# Altium schematic sheet ГРЛМ.468389.001 Э3.SchDoc
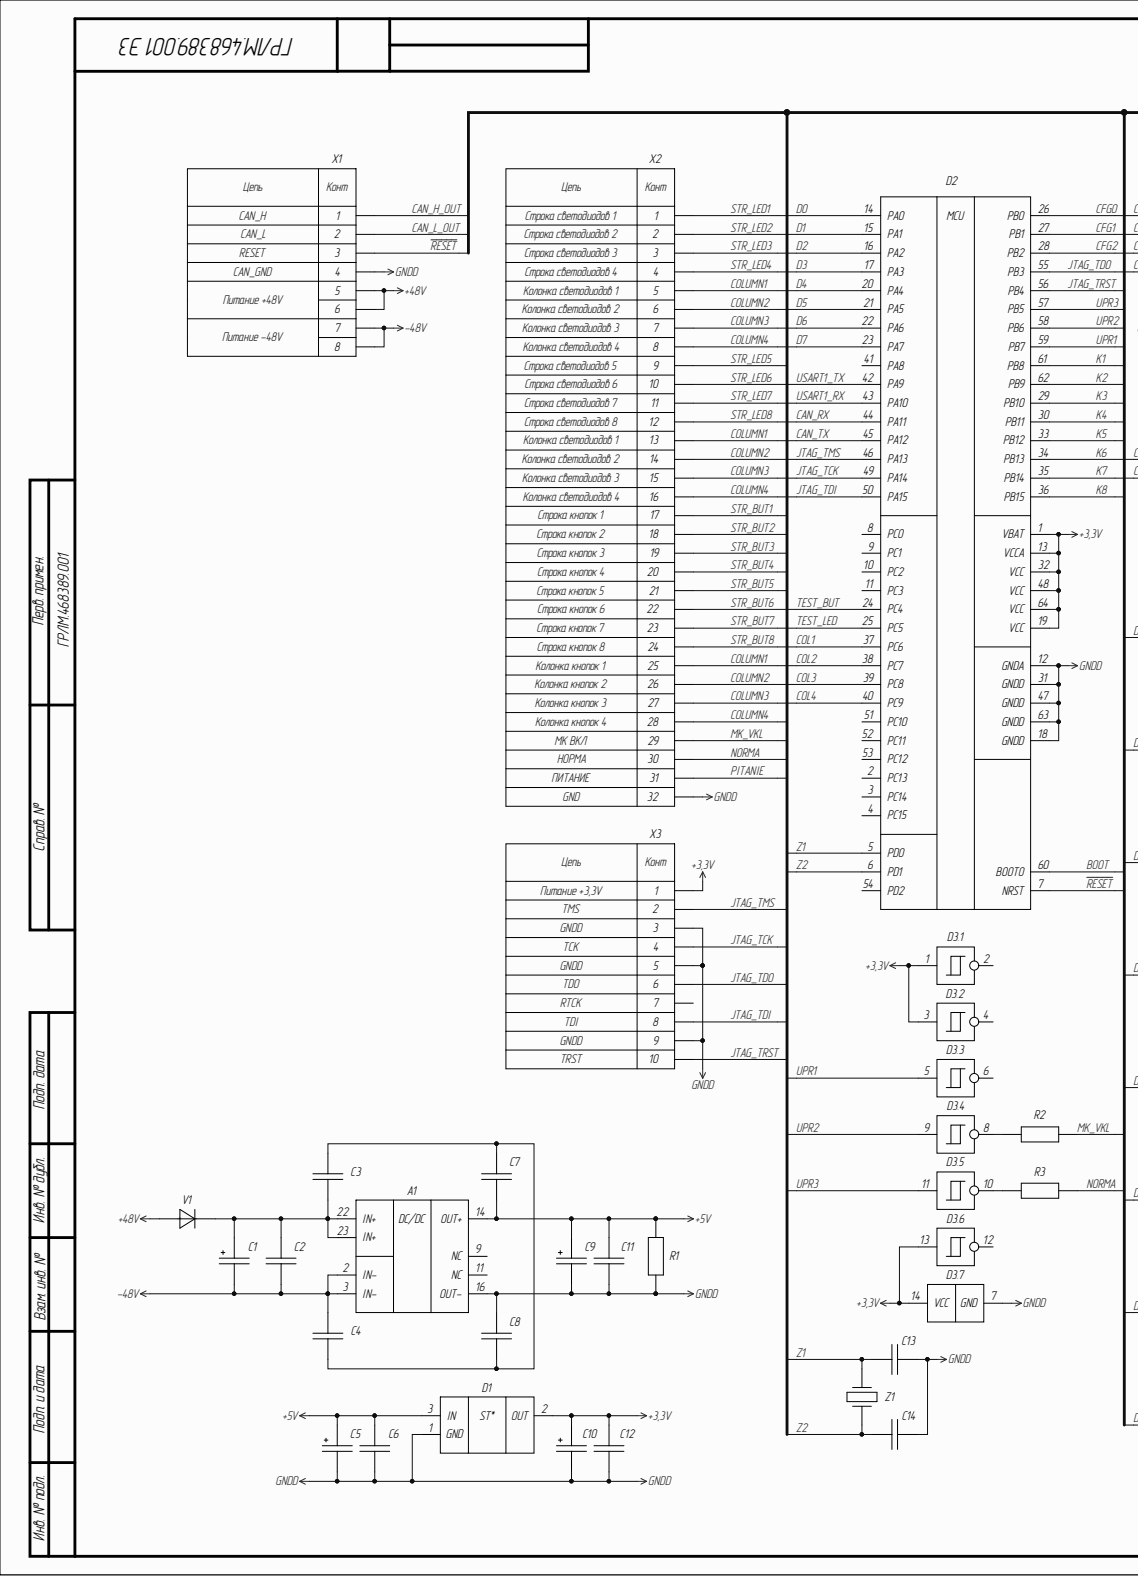
[diagram p0: ГРЛМ.468389.001 Э3.SchDoc, left]
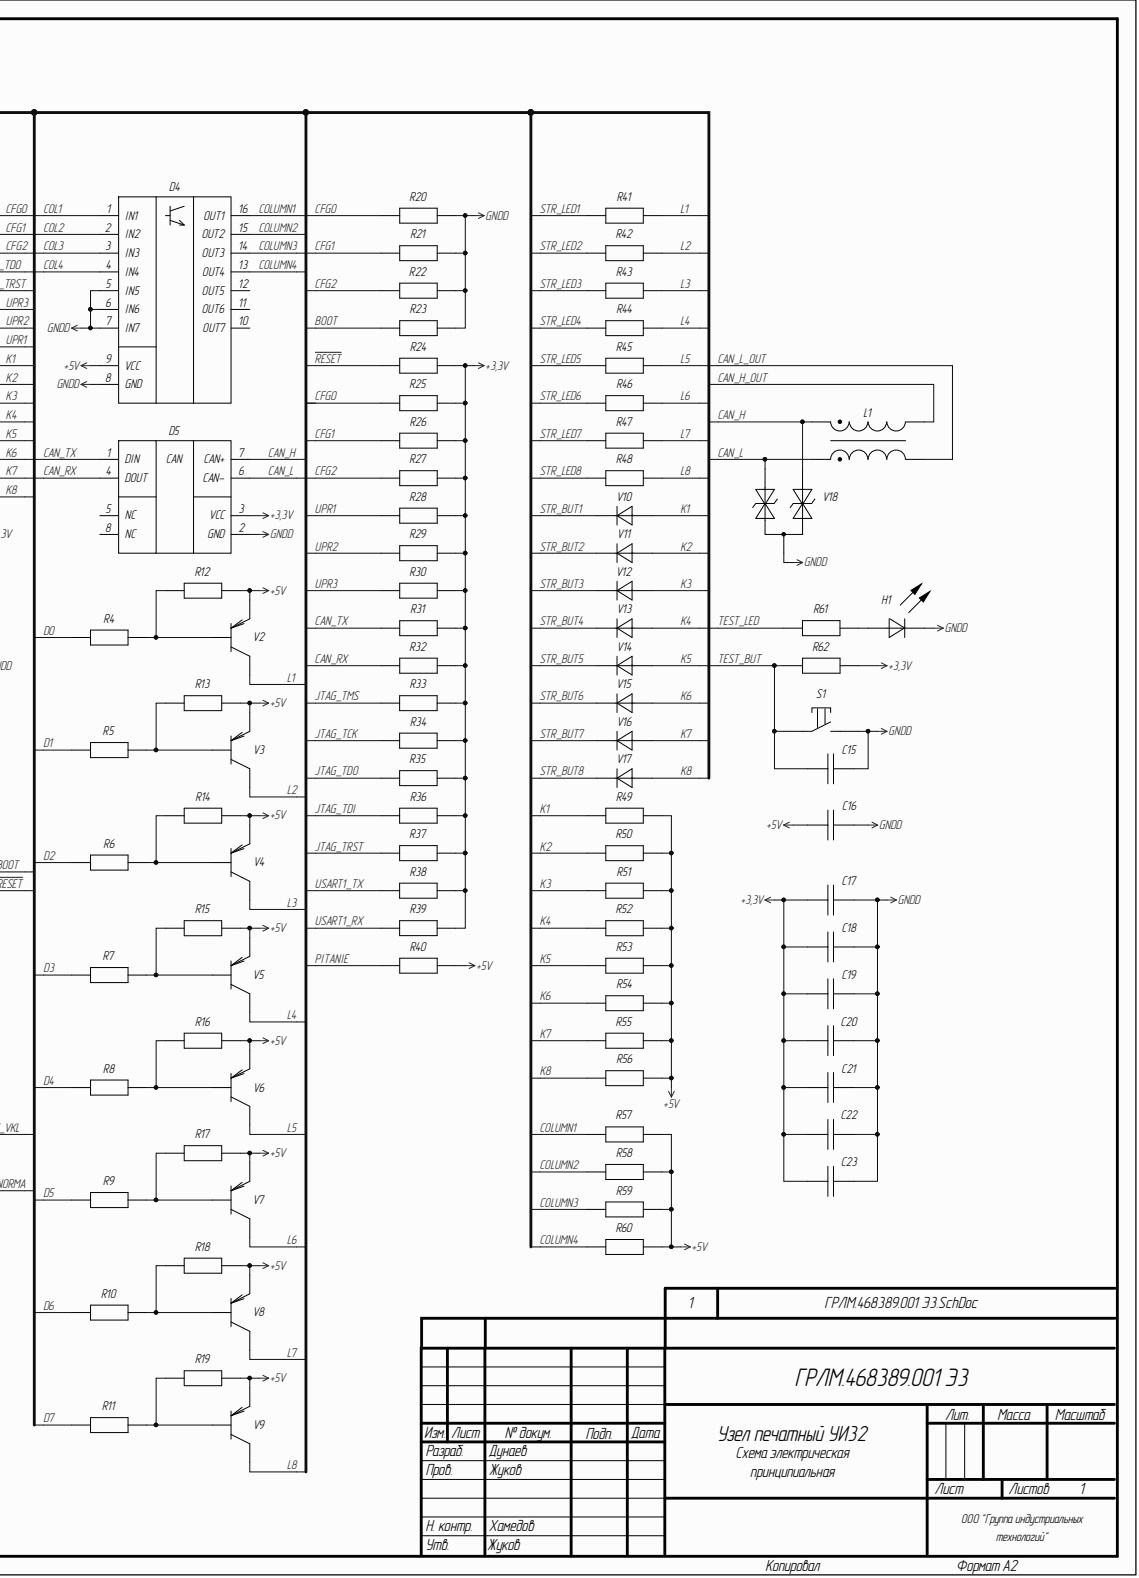
[diagram p1: ГРЛМ.468389.001 Э3.SchDoc, right]

## Parts
A1  SCW05С-05  [SCW05C-05]  (value not drawn)
C1  RADCAP_5x11  [CAPPR500-1000X1600]  (value not drawn)
C2  CERCAP_1206  [CAP1206]  (value not drawn)
C3  CERCAP_1206  [CAP1206]  (value not drawn)
C4  CERCAP_1206  [CAP1206]  (value not drawn)
C5  TANCAP_A  [CAP-T-A]  (value not drawn)
C6  CERCAP_0805  [CAP0805]  (value not drawn)
C7  CERCAP_0805  [CAP0805]  (value not drawn)
C8  CERCAP_0805  [CAP0805]  (value not drawn)
C9  TANCAP_A  [CAP-T-A]  (value not drawn)
C10  TANCAP_A  [CAP-T-A]  (value not drawn)
C11  CERCAP_0805  [CAP0805]  (value not drawn)
C12  CERCAP_0805  [CAP0805]  (value not drawn)
C13  CERCAP_0805  [CAP0805]  (value not drawn)
C14  CERCAP_0805  [CAP0805]  (value not drawn)
C15  CERCAP_0805  [CAP0805]  (value not drawn)
C16  CERCAP_0805  [CAP0805]  (value not drawn)
C17  CERCAP_0805  [CAP0805]  (value not drawn)
C18  CERCAP_0805  [CAP0805]  (value not drawn)
C19  CERCAP_0805  [CAP0805]  (value not drawn)
C20  CERCAP_0805  [CAP0805]  (value not drawn)
C21  CERCAP_0805  [CAP0805]  (value not drawn)
C22  CERCAP_0805  [CAP0805]  (value not drawn)
C23  CERCAP_0805  [CAP0805]  (value not drawn)
D1  LM1117IDTX-3.3/NOPB  [LM1117IDTX]  (value not drawn)
D2  STM32F103RBT6  [QFP50P1200X1200X160-64N]  (value not drawn)
D3  SN74HC14D  [SOIC127P600X175-14N]  (value not drawn)  (parts .1,.2,.3,.4,.5,.6,.7)
D4  ULN2003A  [SOIC127P600X175-16N]  (value not drawn)
D5  SN65HVD232D  [SOIC127P600X175-8N]  (value not drawn)
H1  HSMC-C170  [HSMC-C170]  (value not drawn)
L1  B82790S0513N201  [B82789S0]  (value not drawn)
R1  RES_1206  [RES1206]  (value not drawn)
R2  RES_0805  [RES0805]  (value not drawn)
R3  RES_0805  [RES0805]  (value not drawn)
R4  RES_0805  [RES0805]  (value not drawn)
R5  RES_0805  [RES0805]  (value not drawn)
R6  RES_0805  [RES0805]  (value not drawn)
R7  RES_0805  [RES0805]  (value not drawn)
R8  RES_0805  [RES0805]  (value not drawn)
R9  RES_0805  [RES0805]  (value not drawn)
R10  RES_0805  [RES0805]  (value not drawn)
R11  RES_0805  [RES0805]  (value not drawn)
R12  RES_0805  [RES0805]  (value not drawn)
R13  RES_0805  [RES0805]  (value not drawn)
R14  RES_0805  [RES0805]  (value not drawn)
R15  RES_0805  [RES0805]  (value not drawn)
R16  RES_0805  [RES0805]  (value not drawn)
R17  RES_0805  [RES0805]  (value not drawn)
R18  RES_0805  [RES0805]  (value not drawn)
R19  RES_0805  [RES0805]  (value not drawn)
R20  RES_0805  [RES0805]  (value not drawn)
R21  RES_0805  [RES0805]  (value not drawn)
R22  RES_0805  [RES0805]  (value not drawn)
R23  RES_0805  [RES0805]  (value not drawn)
R24  RES_0805  [RES0805]  (value not drawn)
R25  RES_0805  [RES0805]  (value not drawn)
R26  RES_0805  [RES0805]  (value not drawn)
R27  RES_0805  [RES0805]  (value not drawn)
R28  RES_0805  [RES0805]  (value not drawn)
R29  RES_0805  [RES0805]  (value not drawn)
R30  RES_0805  [RES0805]  (value not drawn)
R31  RES_0805  [RES0805]  (value not drawn)
R32  RES_0805  [RES0805]  (value not drawn)
R33  RES_0805  [RES0805]  (value not drawn)
R34  RES_0805  [RES0805]  (value not drawn)
R35  RES_0805  [RES0805]  (value not drawn)
R36  RES_0805  [RES0805]  (value not drawn)
R37  RES_0805  [RES0805]  (value not drawn)
R38  RES_0805  [RES0805]  (value not drawn)
R39  RES_0805  [RES0805]  (value not drawn)
R40  RES_0805  [RES0805]  (value not drawn)
R41  RES_1206  [RES1206]  (value not drawn)
R42  RES_1206  [RES1206]  (value not drawn)
R43  RES_1206  [RES1206]  (value not drawn)
R44  RES_1206  [RES1206]  (value not drawn)
R45  RES_1206  [RES1206]  (value not drawn)
R46  RES_1206  [RES1206]  (value not drawn)
R47  RES_1206  [RES1206]  (value not drawn)
R48  RES_1206  [RES1206]  (value not drawn)
R49  RES_0805  [RES0805]  (value not drawn)
R50  RES_0805  [RES0805]  (value not drawn)
R51  RES_0805  [RES0805]  (value not drawn)
R52  RES_0805  [RES0805]  (value not drawn)
R53  RES_0805  [RES0805]  (value not drawn)
R54  RES_0805  [RES0805]  (value not drawn)
R55  RES_0805  [RES0805]  (value not drawn)
R56  RES_0805  [RES0805]  (value not drawn)
R57  RES_0805  [RES0805]  (value not drawn)
R58  RES_0805  [RES0805]  (value not drawn)
R59  RES_0805  [RES0805]  (value not drawn)
R60  RES_0805  [RES0805]  (value not drawn)
R61  RES_0805  [RES0805]  (value not drawn)
R62  RES_0805  [RES0805]  (value not drawn)
S1  DTSM-32  [DTSM-3]  (value not drawn)
V1  G1B  [SOD-123FL]  (value not drawn)
V2  BC807  [SOT95P251X112-3N]  (value not drawn)
V3  BC807  [SOT95P251X112-3N]  (value not drawn)
V4  BC807  [SOT95P251X112-3N]  (value not drawn)
V5  BC807  [SOT95P251X112-3N]  (value not drawn)
V6  BC807  [SOT95P251X112-3N]  (value not drawn)
V7  BC807  [SOT95P251X112-3N]  (value not drawn)
V8  BC807  [SOT95P251X112-3N]  (value not drawn)
V9  BC807  [SOT95P251X112-3N]  (value not drawn)
V10  LL4148  [LL4148]  (value not drawn)
V11  LL4148  [LL4148]  (value not drawn)
V12  LL4148  [LL4148]  (value not drawn)
V13  LL4148  [LL4148]  (value not drawn)
V14  LL4148  [LL4148]  (value not drawn)
V15  LL4148  [LL4148]  (value not drawn)
V16  LL4148  [LL4148]  (value not drawn)
V17  LL4148  [LL4148]  (value not drawn)
V18  NUP2105L  [BC850B.215]  (value not drawn)
X1  IDC-8MS  [SAMTEC_TSS-104-01-G-D]  (value not drawn)
X2  32FMN-BMTTR-A-TB  [32FMN-BTK-1]  (value not drawn)
X3  IDC-10MS  [3M_N2510-6003RB]  (value not drawn)
Z1  HC-49SM  [KX-3H]  (value not drawn)

## Nets
+3,3V: C10.1 C12.2 C17.1 C18.1 C19.1 C20.1 C21.1 C22.1 C23.1 D1.2 D2.1(VBAT) D2.13(VCCA) D2.19(VCC) D2.32(VCC) D2.48(VCC) D2.64(VCC) D3.1 D3.3 D3.13 D3.14(VCC) D5.3(VCC) R24.2 R25.2 R26.2 R27.2 R28.2 R29.2 R30.2 R31.2 R32.2 R33.1 R34.1 R35.1 R36.1 R37.1 R38.1 R39.1 R62.2 X3.1
+5V: A1.14(OUT+) C5.1 C6.2 C7.1 C9.1 C11.2 C16.1 D1.3 D4.9(VCC) R1.2 R12.2 R13.2 R14.2 R15.2 R16.2 R17.2 R18.2 R19.2 R40.2 R49.2 R50.2 R51.2 R52.2 R53.2 R54.2 R55.2 R56.2 R57.2 R58.2 R59.2 R60.2 V2.2 V3.2 V4.2 V5.2 V6.2 V7.2 V8.2 V9.2
+48V: V1.2 X1.5 X1.6
-48V: A1.2(IN-) A1.3(IN-) C1.2 C2.1 C4.2 X1.7 X1.8
GNDD: A1.16(OUT-) C5.2 C6.1 C8.2 C9.2 C10.2 C11.1 C12.1 C13.2 C14.2 C15.2 C16.2 C17.2 C18.2 C19.2 C20.2 C21.2 C22.2 C23.2 D1.1 D2.12(GNDA) D2.18(GNDD) D2.31(GNDD) D2.47(GNDD) D2.63(GNDD) D3.7(GND) D4.5(IN5) D4.6(IN6) D4.7(IN7) D4.8(GND) D5.2(GND) H1.1 R1.1 R20.2 R21.2 R22.2 R23.2 S1.2 V18.3 X1.4 X2.32 X3.3 X3.5 X3.9
BOOT: D2.60(BOOT0) R23.1
CAN_H: D5.7(CAN+) L1.1 V18.2
CAN_H_OUT: L1.2 X1.1
CAN_L: D5.6(CAN-) L1.4 V18.1
CAN_L_OUT: L1.3 X1.2
CAN_RX: D2.44(PA11) D5.4(DOUT) R32.1
CAN_TX: D2.45(PA12) D5.1(DIN) R31.1
CFG0: D2.26(PB0) R20.1 R25.1
CFG1: D2.27(PB1) R21.1 R26.1
CFG2: D2.28(PB2) R22.1 R27.1
COL1: D2.37(PC6) D4.1(IN1)
COL2: D2.38(PC7) D4.2(IN2)
COL3: D2.39(PC8) D4.3(IN3)
COL4: D2.40(PC9) D4.4(IN4)
COLUMN1: D4.16(OUT1) R57.1 X2.5 X2.13 X2.25
COLUMN2: D4.15(OUT2) R58.1 X2.6 X2.14 X2.26
COLUMN3: D4.14(OUT3) R59.1 X2.7 X2.15 X2.27
COLUMN4: D4.13(OUT4) R60.1 X2.8 X2.16 X2.28
D0: D2.14(PA0) R4.1
D1: D2.15(PA1) R5.1
D2: D2.16(PA2) R6.1
D3: D2.17(PA3) R7.1
D4: D2.20(PA4) R8.1
D5: D2.21(PA5) R9.1
D6: D2.22(PA6) R10.1
D7: D2.23(PA7) R11.1
JTAG_TCK: D2.49(PA14) R34.2 X3.4
JTAG_TDI: D2.50(PA15) R36.2 X3.8
JTAG_TDO: D2.55(PB3) R35.2 X3.6
JTAG_TMS: D2.46(PA13) R33.2 X3.2
JTAG_TRST: D2.56(PB4) R37.2 X3.10
K1: D2.61(PB8) R49.1 V10.2
K2: D2.62(PB9) R50.1 V11.2
K3: D2.29(PB10) R51.1 V12.2
K4: D2.30(PB11) R52.1 V13.2
K5: D2.33(PB12) R53.1 V14.2
K6: D2.34(PB13) R54.1 V15.2
K7: D2.35(PB14) R55.1 V16.2
K8: D2.36(PB15) R56.1 V17.2
L1: R41.2 V2.3
L2: R42.2 V3.3
L3: R43.2 V4.3
L4: R44.2 V5.3
L5: R45.2 V6.3
L6: R46.2 V7.3
L7: R47.2 V8.3
L8: R48.2 V9.3
MK_VKL: R2.2 X2.29
NORMA: R3.2 X2.30
PITANIE: R40.1 X2.31
~{RESET}: D2.7(NRST) R24.1 X1.3
STR_BUT1: V10.1 X2.17
STR_BUT2: V11.1 X2.18
STR_BUT3: V12.1 X2.19
STR_BUT4: V13.1 X2.20
STR_BUT5: V14.1 X2.21
STR_BUT6: V15.1 X2.22
STR_BUT7: V16.1 X2.23
STR_BUT8: V17.1 X2.24
STR_LED1: R41.1 X2.1
STR_LED2: R42.1 X2.2
STR_LED3: R43.1 X2.3
STR_LED4: R44.1 X2.4
STR_LED5: R45.1 X2.9
STR_LED6: R46.1 X2.10
STR_LED7: R47.1 X2.11
STR_LED8: R48.1 X2.12
TEST_BUT: C15.1 D2.24(PC4) R62.1 S1.1
TEST_LED: D2.25(PC5) R61.2
UPR1: D2.59(PB7) D3.5 R28.1
UPR2: D2.58(PB6) D3.9 R29.1
UPR3: D2.57(PB5) D3.11 R30.1
USART1_RX: D2.43(PA10) R39.2
USART1_TX: D2.42(PA9) R38.2
Z1: C13.1 D2.5(PD0) Z1.2
Z2: C14.1 D2.6(PD1) Z1.1
NetA1_22: A1.22(IN+) A1.23(IN+) C1.1 C2.2 C3.1 V1.1
NetC3_2: C3.2 C4.1 C7.2 C8.1
NetD3_8: D3.8 R2.1
NetD3_10: D3.10 R3.1
NetH1_2: H1.2 R61.1
NetR4_2: R4.2 R12.1 V2.1
NetR5_2: R5.2 R13.1 V3.1
NetR6_2: R6.2 R14.1 V4.1
NetR7_2: R7.2 R15.1 V5.1
NetR8_2: R8.2 R16.1 V6.1
NetR9_2: R9.2 R17.1 V7.1
NetR10_2: R10.2 R18.1 V8.1
NetR11_2: R11.2 R19.1 V9.1
N00054:

Not connected: A1 9(NC) 11(NC); D2 2(PC13) 3(PC14) 4(PC15) 8(PC0) 9(PC1) 10(PC2) 11(PC3) 41(PA8) 51(PC10) 52(PC11) 53(PC12) 54(PD2); D3 2 4 6 12; D4 10(OUT7) 11(OUT6) 12(OUT5); D5 5(NC) 8(NC); X3 7

## Library data of the parts
A1 = SCW05С-05: Модуль питания; Артикул: арт. SCW05С-05; Наименование: Модуль питания SCW05C-05; Производитель: MEAN WELL; Component Kind: Standard; Library Name: Модули.SchLib
C1 = RADCAP_5x11: Конденсатор электролитический радиальный; Value: 47,0x100V; Артикул: арт. MAL213669479E3; Наименование: 47 мкФ±20%-100В, 10x16, RM5,0; Производитель: VISHAY; Component Kind: Standard; Library Name: ГРЛМ.468389.001.SCHLIB
C2-C4 = CERCAP_1206: Конденсатор керамический; Value: 0.1x100V; Артикул: арт. CC1206KKX7R0BB104; Наименование: 1206-0,1 мкФ±10%-100В-X7R; Производитель: YAGEO; Component Kind: Standard; Library Name: Конденсаторы.SchLib
C5 C9 C10 = TANCAP_A: Конденсатор танталовый; Value: 10,0x6,3V; Артикул: арт. TAJA106K006RNJ; Наименование: 10 мкФ±10%-6,3В, тип A; Производитель: AVX; Component Kind: Standard; Library Name: ГРЛМ.468389.001.SCHLIB
C6-C8 C11 C12 C15-C23 = CERCAP_0805: Конденсатор керамический; Value: 0.1; Артикул: арт. CC0805KPX7R9BB104; Наименование: 0805-0,1 мкФ±10%-50В-X7R; Производитель: YAGEO; Component Kind: Standard; Library Name: Конденсаторы.SchLib
C13 C14 = CERCAP_0805: Конденсатор керамический; Value: 6.2; Артикул: арт. C0805C629C5GACTU; Наименование: 0805-6,2 пФ±5%-50В-NP0; Производитель: YAGEO; Component Kind: Standard; Library Name: Конденсаторы.SchLib
D1 = LM1117IDTX-3.3/NOPB: Стабилизатор 3,3В; Артикул: арт. LM1117IDTX-3.3/NOPB; Производитель: Texas Instruments; Component Kind: Standard; Наименование: LM1117IDTX-3.3/NOPB; Library Name: Микросхемы.SchLib
D2 = STM32F103RBT6: Микроконтроллер; Артикул: арт. STM32F103RBT6; Производитель: ST  Microelectronics; Component Kind: Standard; Наименование: STM32F103RBT6; Library Name: ГРЛМ.468389.001.SCHLIB
D3 = SN74HC14D: 6 элементов НЕ; Артикул: арт. SN74HC14D; Производитель: Texas Instruments; Component Kind: Standard; Наименование: SN74HC14D; Library Name: Микросхемы.SchLib
D4 = ULN2003A: Транзисторы Дарлингтона; Артикул: арт. ULQ2003ADR; Наименование: ULQ2003ADR; Производитель: Texas Instruments; Component Kind: Standard; Library Name: Микросхемы.SchLib
D5 = SN65HVD232D: Трансейвер CAN; Артикул: арт. SN65HVD232D; Производитель: Texas Instruments; Component Kind: Standard; Наименование: SN65HVD232D; Library Name: Микросхемы.SchLib
H1 = HSMC-C170: Светодиод SMD красный; Артикул: арт. HSMC-C170-T0000; Наименование: Светодиод HSMC-C170-T0000; Производитель: Broadcom Limited; Component Kind: Standard; Library Name: ГРЛМ.468389.001.SCHLIB
L1 = B82790S0513N201: Дроссель CAN; Артикул: арт. B82790S0513N201; Наименование: Дроссель 2x51 мкГн±30%; Производитель: EPCOS; Component Kind: Standard; Library Name: Индуктивные элементы.SchLib
R1 = RES_1206: Резистор SMD; Value: 510; Артикул: арт. RC1206JR-07510RL; Наименование: 1206-510 Ом±5%; Производитель: YAGEO; Component Kind: Standard; Library Name: Резисторы.SchLib
R2 R3 = RES_0805: Резистор SMD; Value: 470; Артикул: арт. RC0805JR-07470RL; Наименование: 0805-470 Ом±5%; Производитель: YAGEO; Component Kind: Standard; Library Name: Резисторы.SchLib
R4-R11 = RES_0805: Резистор SMD; Value: 2k; Артикул: арт. RC0805JR-072KL; Наименование: 0805-2 кОм±5%; Производитель: YAGEO; Component Kind: Standard; Library Name: Резисторы.SchLib
R12-R19 = RES_0805: Резистор SMD; Value: 1k; Артикул: арт. RC0805JR-071KL; Наименование: 0805-1 кОм±5%; Производитель: YAGEO; Component Kind: Standard; Library Name: Резисторы.SchLib
R20-R22 = RES_0805: Резистор SMD; Value: 0; Артикул: арт. RC0805JR-070RL; Наименование: 0805-0 Ом±5%; Производитель: YAGEO; Component Kind: Standard; Library Name: Резисторы.SchLib
R23 R25-R39 R49-R56 R62 = RES_0805: Резистор SMD; Value: 3,3k; Артикул: арт. RC0805JR-073K3L; Наименование: 0805-3,3 кОм±5%; Производитель: YAGEO; Component Kind: Standard; Library Name: Резисторы.SchLib
R24 = RES_0805: Резистор SMD; Value: 10k; Артикул: арт. RC0805JR-0710KL; Наименование: 0805-10 кОм±5%; Производитель: YAGEO; Component Kind: Standard; Library Name: Резисторы.SchLib
R40 = RES_0805: Резистор SMD; Value: 330; Артикул: арт. RC0805JR-07330RL; Наименование: 0805-330 Ом±5%; Производитель: YAGEO; Component Kind: Standard; Library Name: Резисторы.SchLib
R41-R48 = RES_1206: Резистор SMD; Value: 200; Артикул: арт. RC1206JR-07200RL; Наименование: 1206-200 Ом±5%; Производитель: YAGEO; Component Kind: Standard; Library Name: Резисторы.SchLib
R57-R60 = RES_0805: Резистор SMD; Value: 5,1k; Артикул: арт. RC0805JR-075K1L; Наименование: 0805-5,1 кОм±5%; Производитель: YAGEO; Component Kind: Standard; Library Name: Резисторы.SchLib
R61 = RES_0805: Резистор SMD; Value: 220; Артикул: арт. RC0805JR-07220RL; Наименование: 0805-220 Ом±5%; Производитель: YAGEO; Component Kind: Standard; Library Name: Резисторы.SchLib
S1 = DTSM-32: Кнопка тактовая; Артикул: арт. DTSM-32; Наименование: Кнопка тактовая DTSM-32; Производитель: DIPTRONICS; Component Kind: Standard; Library Name: Коммутационные изделия.SchLib
V1 = G1B: Диод выпрямительный; Артикул: арт. G1B; Наименование: Диод G1B; Производитель: Yangjie Technology; Component Kind: Standard; Library Name: ГРЛМ.468389.001.SCHLIB
V2-V9 = BC807: Транзистор PNP; Артикул: арт. BC807-40.215; Наименование: Транзистор BC807-40.215; Производитель: NXP Semiconductor; Component Kind: Standard; Library Name: Транзисторы.SchLib
V10-V17 = LL4148: Диод выпрямительный; Артикул: арт. LL4148; Наименование: Диод LL4148; Производитель: VISHAY; Component Kind: Standard; Library Name: ГРЛМ.468389.001.SCHLIB
V18 = NUP2105L: Диод сдвоенный; Артикул: арт. NUP2105LT1G; Наименование: Диодная сборка NUP2105LT1G; Производитель: ON Semiconductor; Component Kind: Standard; Library Name: Полупроводники.SchLib
X1 = IDC-8MS: Вилка; Артикул: арт. IDC-8MS; Наименование: Вилка IDC-8MS; Производитель: CONNFLY; Component Kind: Standard; Library Name: Соединители.SchLib
X2 = 32FMN-BMTTR-A-TB: Розетка; Артикул: арт. 32FMN-BMTTR-A-TB; Производитель: JST; Component Kind: Standard; Наименование: 32FMN-BMTTR-A-TB; Library Name: Соединители.SchLib
X3 = IDC-10MS: Вилка; Артикул: арт. IDC-10MS; Наименование: Вилка IDC-10MS; Производитель: Connfly; Component Kind: Standard; Library Name: Соединители.SchLib
Z1 = HC-49SM: Кварцевый резонатор; Value: 8 МГц; Артикул: арт. KX-3HT HC-49/U8H 8 MHz±30ppm; Наименование: Резонатор кварцевый KX-3HT HC-49/U8H 8 MHz±30ppm; Производитель: Geyer Electronic; Component Kind: Standard; Library Name: Резонаторы_Фильтры.SchLib
